# Altium schematic sheet TPVSI_Power_Stage.SchDoc(TPVSI_Power_Stage)
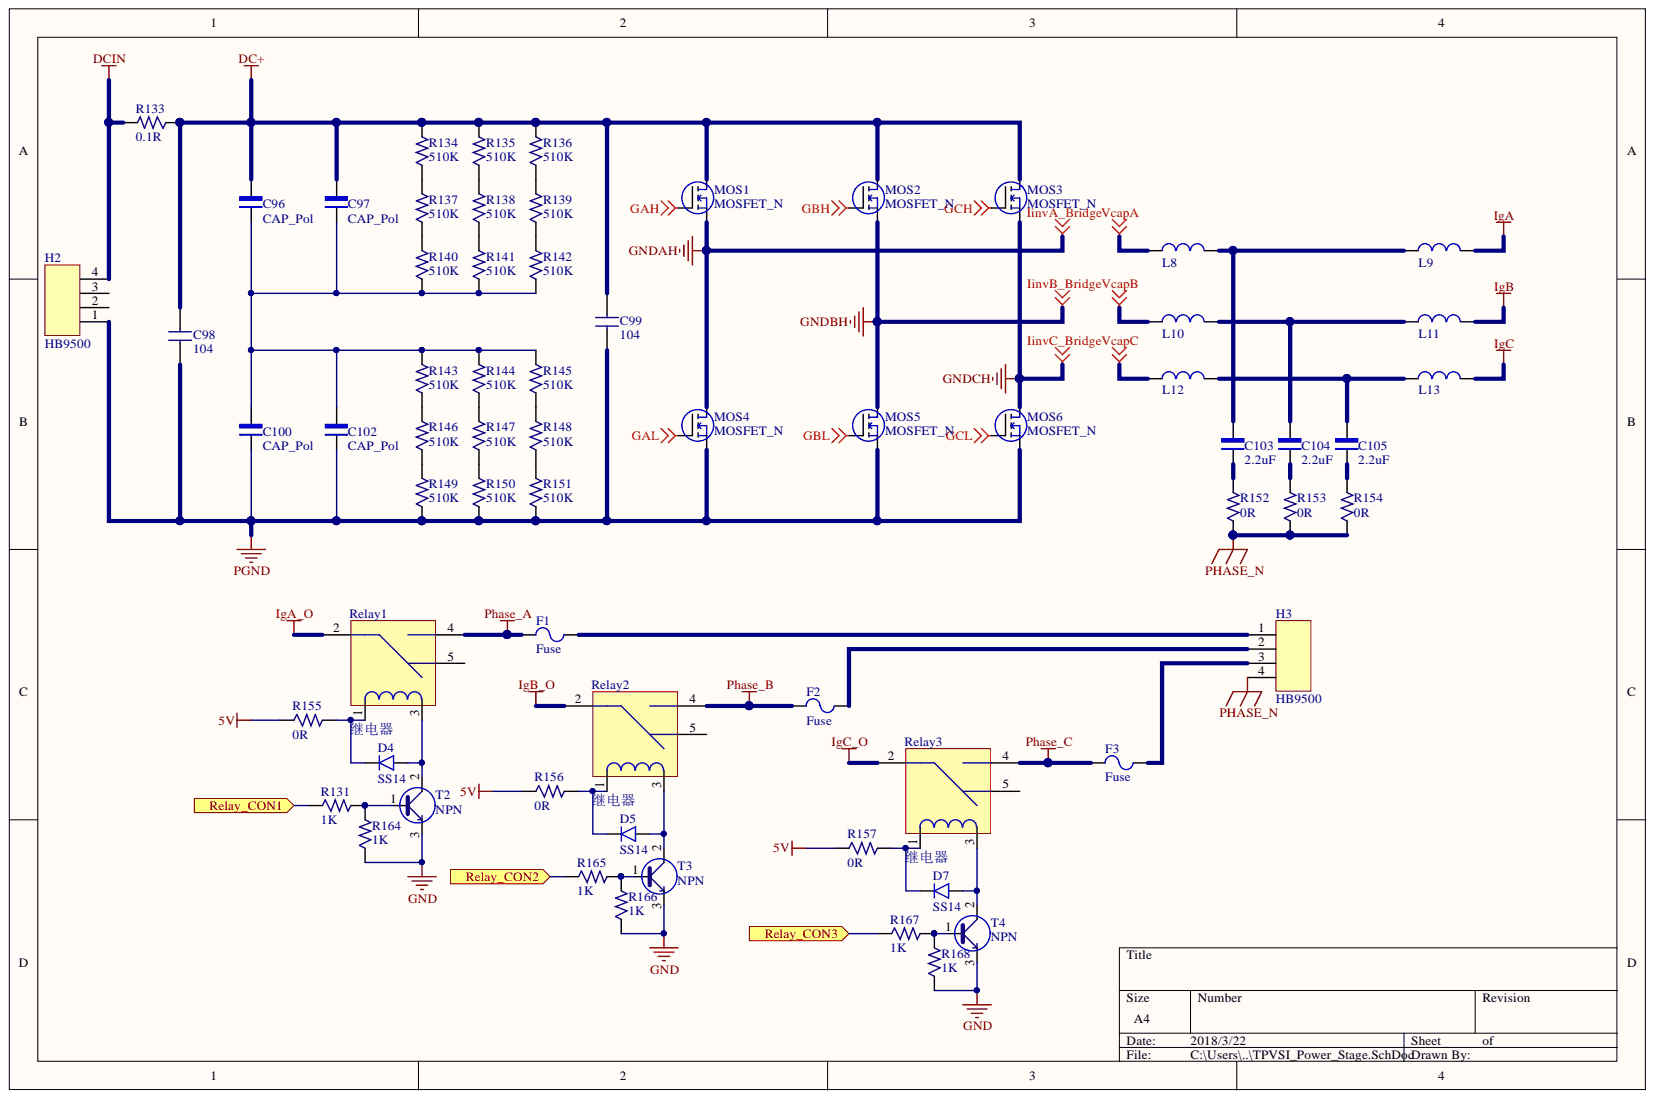
[diagram p0: TPVSI_Power_Stage.SchDoc]

## Parts
C96  CAP_Pol  [CapPol_35x60]
C97  CAP_Pol  [CapPol_35x60]
C98  104  [CAP_25mm_GAP]
C99  104  [CAP_25mm_GAP]
C100  CAP_Pol  [CapPol_35x60]
C102  CAP_Pol  [CapPol_35x60]
C103  2.2uF  [SRB600V2.2uF]
C104  2.2uF  [SRB600V2.2uF]
C105  2.2uF  [SRB600V2.2uF]
D4  SS14  [DIODE-SMA]
D5  SS14  [DIODE-SMA]
D7  SS14  [DIODE-SMA]
F1  Fuse  [FUSE_DIP]
F2  Fuse  [FUSE_DIP]
F3  Fuse  [FUSE_DIP]
H2  HB9500  [HB9500_4P]
H3  HB9500  [HB9500_4P]
L8  inductor  [Inductor_45*15]  (value not drawn)
L9  inductor  [Inductor_45*15]  (value not drawn)
L10  inductor  [Inductor_45*15]  (value not drawn)
L11  inductor  [Inductor_45*15]  (value not drawn)
L12  inductor  [Inductor_45*15]  (value not drawn)
L13  inductor  [Inductor_45*15]  (value not drawn)
MOS1  MOSFET_N  [TO-247-H]
MOS2  MOSFET_N  [TO-247-H]
MOS3  MOSFET_N  [TO-247-H]
MOS4  MOSFET_N  [TO-247-H]
MOS5  MOSFET_N  [TO-247-H]
MOS6  MOSFET_N  [TO-247-H]
R131  1K  [0603_1]
R133  0.1R  [2512]
R134  510K  [1206]
R135  510K  [1206]
R136  510K  [1206]
R137  510K  [1206]
R138  510K  [1206]
R139  510K  [1206]
R140  510K  [1206]
R141  510K  [1206]
R142  510K  [1206]
R143  510K  [1206]
R144  510K  [1206]
R145  510K  [1206]
R146  510K  [1206]
R147  510K  [1206]
R148  510K  [1206]
R149  510K  [1206]
R150  510K  [1206]
R151  510K  [1206]
R152  0R  [2512]
R153  0R  [2512]
R154  0R  [2512]
R155  0R  [0603_1]
R156  0R  [0603_1]
R157  0R  [0603_1]
R164  1K  [0603_1]
R165  1K  [0603_1]
R166  1K  [0603_1]
R167  1K  [0603_1]
R168  1K  [0603_1]
Relay1  继电器  [SRD-05DC-SL-C]
Relay2  继电器  [SRD-05DC-SL-C]
Relay3  继电器  [SRD-05DC-SL-C]
T2  NPN  [SOT-23]
T3  NPN  [SOT-23]
T4  NPN  [SOT-23]

## Ports
Relay_CON1, Relay_CON2, Relay_CON3

## Nets
5V: R155.1 R156.1 R157.1
DC+: C96.1 C97.1 C98.2 C99.2 MOS1.D MOS2.D MOS3.D R133.1 R134.2 R135.2 R136.2
DCIN: H2.4 R133.2
GAH: MOS1.G
GAL: MOS4.G
GBH: MOS2.G
GBL: MOS5.G
GCH: MOS3.G
GCL: MOS6.G
GND: R164.1 R166.1 R168.1 T2.3 T3.3 T4.3
IgA: L9.2
IgA_O: Relay1.2
IgB: L11.2
IgB_O: Relay2.2
IgC: L13.2
IgC_O: Relay3.2
IinvA_Bridge: MOS1.S MOS4.D
IinvB_Bridge: MOS2.S MOS5.D
IinvC_Bridge: MOS3.S MOS6.D
PGND: C98.1 C99.1 C100.2 C102.2 H2.1 MOS4.S MOS5.S MOS6.S R149.1 R150.1 R151.1
Phase_A: F1.1 Relay1.4
Phase_B: F2.1 Relay2.4
Phase_C: F3.1 Relay3.4
PHASE_N: H3.4 R152.1 R153.1 R154.1
VcapA: L8.1
VcapB: L10.1
VcapC: L12.1
Relay_CON1: R131.1 ; port Relay_CON1
Relay_CON2: R165.1 ; port Relay_CON2
Relay_CON3: R167.1 ; port Relay_CON3
NetC96_2: C96.2 C97.2 C100.1 C102.1 R140.1 R141.1 R142.1 R143.2 R144.2 R145.2
NetC103_1: C103.1 L8.2 L9.1
NetC103_2: C103.2 R152.2
NetC104_1: C104.1 L10.2 L11.1
NetC104_2: C104.2 R153.2
NetC105_1: C105.1 L12.2 L13.1
NetC105_2: C105.2 R154.2
NetD4_1: D4.1 Relay1.3 T2.2
NetD4_2: D4.2 R155.2 Relay1.1
NetD5_1: D5.1 Relay2.3 T3.2
NetD5_2: D5.2 R156.2 Relay2.1
NetD7_1: D7.1 Relay3.3 T4.2
NetD7_2: D7.2 R157.2 Relay3.1
NetF1_2: F1.2 H3.1
NetF2_2: F2.2 H3.2
NetF3_2: F3.2 H3.3
NetR131_2: R131.2 R164.2 T2.1
NetR134_1: R134.1 R137.2
NetR135_1: R135.1 R138.2
NetR136_1: R136.1 R139.2
NetR137_1: R137.1 R140.2
NetR138_1: R138.1 R141.2
NetR139_1: R139.1 R142.2
NetR143_1: R143.1 R146.2
NetR144_1: R144.1 R147.2
NetR145_1: R145.1 R148.2
NetR146_1: R146.1 R149.2
NetR147_1: R147.1 R150.2
NetR148_1: R148.1 R151.2
NetR165_2: R165.2 R166.2 T3.1
NetR167_2: R167.2 R168.2 T4.1

Not connected: H2 2 3; Relay1 5; Relay2 5; Relay3 5

## Library data of the parts
C96 C97 C100 C102-C105 = CAP_Pol: CAP; Component Kind: Standard; Library Name: Chu_16.IntLib
C98 C99 = cap: Component Kind: Standard; Library Name: Chu_16.IntLib
D4 D5 D7 = Diode_Schottkey: Component Kind: Standard; Library Name: Chu_16.IntLib
F1-F3 = Fuse: 保险丝10mmx3mm; Component Kind: Standard; Library Name: Chu_16.IntLib
H2 H3 = Header_HB9500_4P: 额定电压300VAC,额定电流30A; Component Kind: Standard; Library Name: Chu_16.IntLib
R131 R133-R157 R164-R168 = Res: Component Kind: Standard; Library Name: Chu_16.IntLib
Relay1-Relay3 = 继电器5V: Component Kind: Standard; Library Name: Chu_16.IntLib
T2-T4 = NPN_BJT: Component Kind: Standard; Library Name: Chu_16.IntLib
L8-L13 = inductor: Component Kind: Standard; Library Name: Chu_16.IntLib
MOS1-MOS6 = MOSFET_N: Description: MOSFET_N; Component Kind: Standard; Library Name: Chu_16.IntLib
